# Altium schematic sheet backplane.SchDoc (continued)
## Nets (continued)
NetRPI_31: RPI.31(GPIO_06) S-I.B5(RPI)
NetRPI_32: RPI.32(GPIO_12) S-J.B5(RPI)
NetRPI_33: RPI.33(GPIO_13) S-F.B5(RPI)
NetRPI_35: RPI.35(GPIO_19) S-E.B5(RPI)
NetRPI_36: RPI.36(GPIO_16) S-D.B5(RPI)
NetRPI_37: RPI.37(GPIO_26) S-C.B5(RPI)
NetRPI_38: RPI.38(GPIO_20) S-B.B5(RPI)
NetRPI_40: RPI.40(GPIO_21) S-A.B5(RPI)
NetS-A_A4: S-A.A4(SS) S-B.A4(SS)
NetS-A_A5: S-A.A5(SCK) S-B.A5(SCK)
NetS-A_B4: S-A.B4(MISO) S-B.B4(MISO)
NetS-A_C4: S-A.C4(MOSI) S-B.C4(MOSI)
NetS-C_A4: S-C.A4(SS) S-D.A4(SS)
NetS-C_A5: S-C.A5(SCK) S-D.A5(SCK)
NetS-C_B4: S-C.B4(MISO) S-D.B4(MISO)
NetS-C_C4: S-C.C4(MOSI) S-D.C4(MOSI)
NetS-E_A4: S-E.A4(SS) S-F.A4(SS)
NetS-E_A5: S-E.A5(SCK) S-F.A5(SCK)
NetS-E_B4: S-E.B4(MISO) S-F.B4(MISO)
NetS-E_C4: S-E.C4(MOSI) S-F.C4(MOSI)
NetS-G_A4: S-G.A4(SS) S-H.A4(SS)
NetS-G_A5: S-G.A5(SCK) S-H.A5(SCK)
NetS-G_B4: S-G.B4(MISO) S-H.B4(MISO)
NetS-G_C4: S-G.C4(MOSI) S-H.C4(MOSI)
NetS-I_A4: S-I.A4(SS) S-J.A4(SS)
NetS-I_A5: S-I.A5(SCK) S-J.A5(SCK)
NetS-I_B4: S-I.B4(MISO) S-J.B4(MISO)
NetS-I_C4: S-I.C4(MOSI) S-J.C4(MOSI)

Not connected: AUNO 3.3V AREF GND1(GND) GND3(GND) IOREF NC RESET SCL SDA VIN; DISP1 3(CC); RPI 1(3.3V) 2(5V) 6(GND) 7(GPIO_04_(GPIO_GCLK)) 9(GND) 11(GPIO_17_(GPIO_GEN0)) 12(GPIO_18_(GPIO_GEN1)) 13(GPIO_27_(GPIO_GEN2)) 14(GND) 16(GPIO_23_(GPIO_GEN4)) 17(3.3V) 18(GPIO_24_(GPIO_GEN5)) 19(GPIO_10_(SPI_MOSI)) 20(GND) 21(GPIO_09_(SPI_MISO)) 22(GPIO_25_(GPIO_GEN6)) 23(GPIO_11_(SPI_CLK)) 24(GPIO_08_(SPI_CE0_N)) 25(GND) 26(GPIO_07_(SPI_CE1_N)) 27(ID_SD_(I2C_ID_EEPROM)) 28(ID_SC_(I2C_ID_EEPROM)) 30(GND) 39(GND); S-A A6(NC) A7(NC); S-B A6(NC) A7(NC); S-C A6(NC) A7(NC); S-D A6(NC) A7(NC); S-E A6(NC) A7(NC); S-F A6(NC) A7(NC); S-G A6(NC) A7(NC); S-H A6(NC) A7(NC); S-I A6(NC) A7(NC); S-J A6(NC) A7(NC) HPIB; SR 9(QH') 13(OE#)
